# Altium schematic sheet Buck-Boost Converter Ver2.1.SchDoc("Buck-Boost Converter Ver2.1")
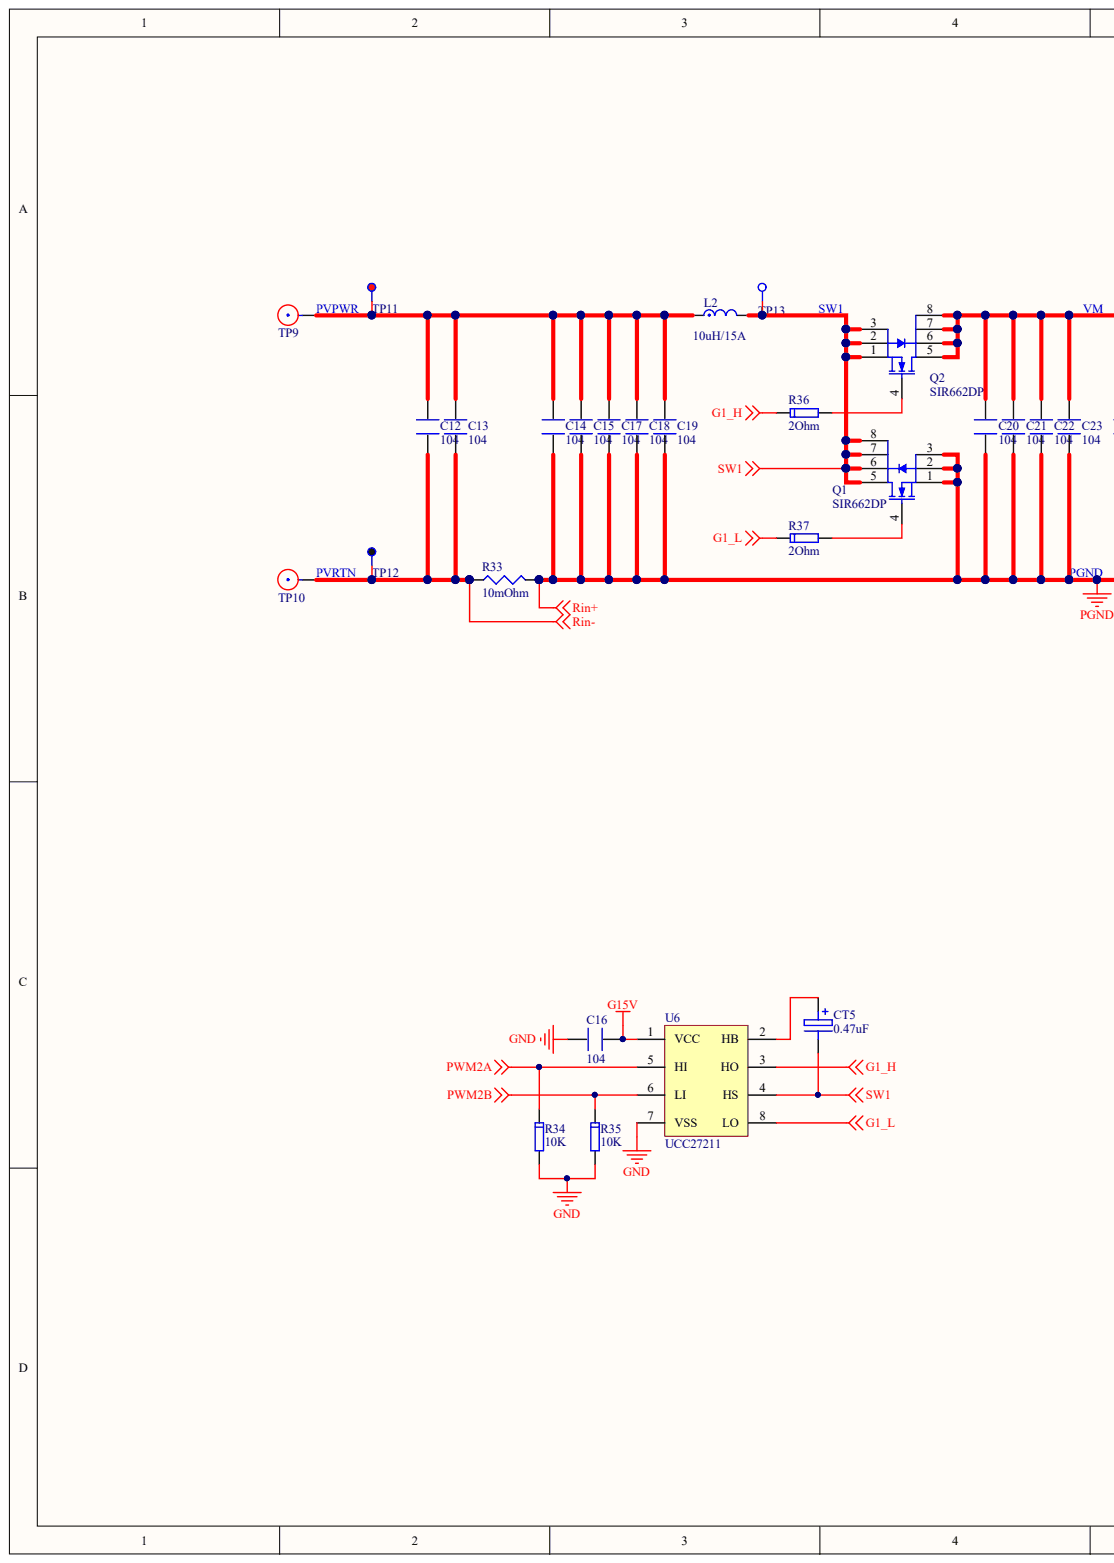
[diagram p0: Buck-Boost Converter Ver2.1.SchDoc, left]
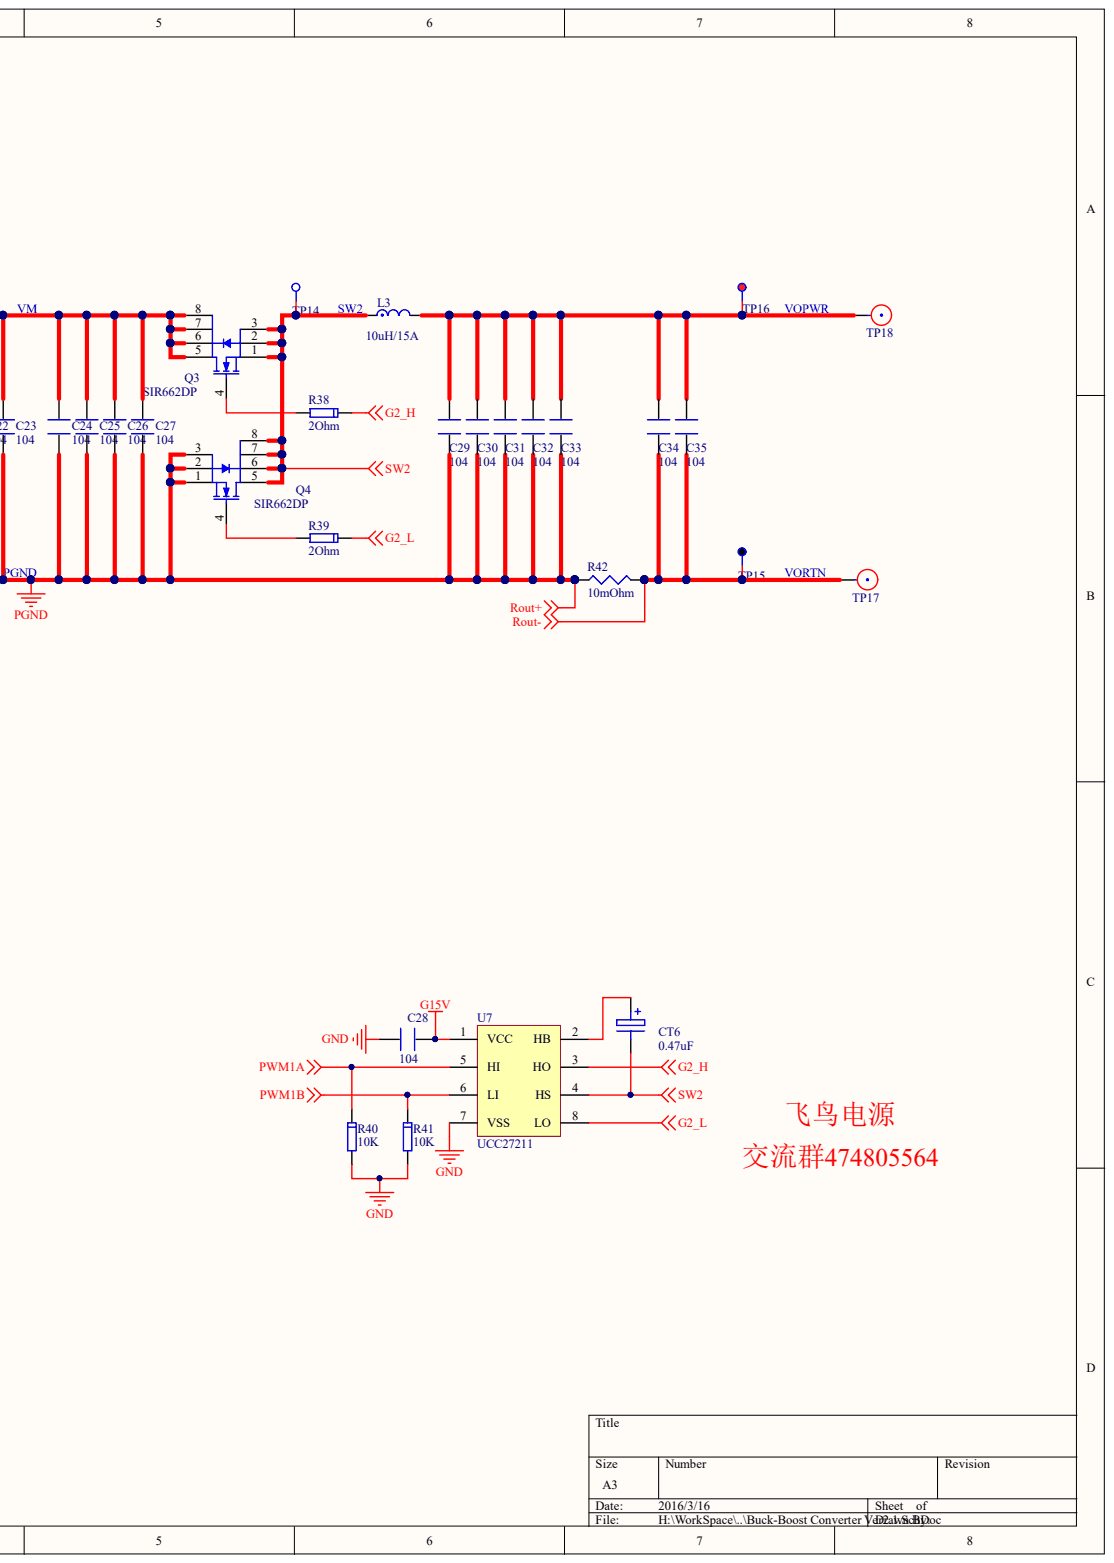
[diagram p1: Buck-Boost Converter Ver2.1.SchDoc, right]

## Parts
C12  104  [C1812]
C13  104  [C1812]
C14  104  [C1812]
C15  104  [C1812]
C16  104  [C0805]
C17  104  [C1812]
C18  104  [C1812]
C19  104  [C1812]
C20  104  [C1210]
C21  104  [C1210]
C22  104  [C1210]
C23  104  [C1210]
C24  104  [C1210]
C25  104  [C1210]
C26  104  [C1210]
C27  104  [C1210]
C28  104  [C0805]
C29  104  [C1812]
C30  104  [C1812]
C31  104  [C1812]
C32  104  [C1812]
C33  104  [C1812]
C34  104  [C1812]
C35  104  [C1812]
CT5  0.47uF  [C1206]
CT6  0.47uF  [C1206]
L2  10uH/15A  [L1260]
L3  10uH/15A  [L1260]
Q1  SIR662DP  [TDSON-8]
Q2  SIR662DP  [TDSON-8]
Q3  SIR662DP  [TDSON-8]
Q4  SIR662DP  [TDSON-8]
R33  10mOhm  [R2512]
R34  10K  [R0603]
R35  10K  [R0603]
R36  2Ohm  [R0603]
R37  2Ohm  [R0603]
R38  2Ohm  [R0603]
R39  2Ohm  [R0603]
R40  10K  [R0603]
R41  10K  [R0603]
R42  10mOhm  [R2512]
TP9  TestPoint  [PCB-11(M3)]  (value not drawn)
TP10  TestPoint  [PCB-11(M3)]  (value not drawn)
TP11  5005  [Keystone5005]  (value not drawn)
TP12  5006  [Keystone5006]  (value not drawn)
TP13  5007  [Keystone5007]  (value not drawn)
TP14  5007  [Keystone5007]  (value not drawn)
TP15  5006  [Keystone5006]  (value not drawn)
TP16  5005  [Keystone5005]  (value not drawn)
TP17  TestPoint  [PCB-11(M3)]  (value not drawn)
TP18  TestPoint  [PCB-11(M3)]  (value not drawn)
U6  UCC27211  [SOP8]
U7  UCC27211  [SOP8]

## Nets
G1_H: R36.1 U6.3(HO)
G1_L: R37.1 U6.8(LO)
G2_H: R38.1 U7.3(HO)
G2_L: R39.1 U7.8(LO)
G15V: C16.1 C28.2 U6.1(VCC) U7.1(VCC)
GND: C16.2 C28.1 R34.2 R35.2 R40.2 R41.2 U6.7(VSS) U7.7(VSS)
PWM1A: R40.1 U7.5(HI)
PWM1B: R41.1 U7.6(LI)
PWM2A: R34.1 U6.5(HI)
PWM2B: R35.1 U6.6(LI)
PGND: C14.2 C15.2 C17.2 C18.2 C19.2 C20.2 C21.2 C22.2 C23.2 C24.2 C25.2 C26.2 C27.2 C29.2 C30.2 C31.2 C32.2 C33.2 Q1.1 Q1.2 Q1.3 Q4.1 Q4.2 Q4.3 R33.2 R42.1
PVPWR: C12.1 C13.1 C14.1 C15.1 C17.1 C18.1 C19.1 L2.1 TP9.1 TP11.1
PVRTN: C12.2 C13.2 R33.1 TP10.1 TP12.1
SW1: CT5.2 L2.2 Q1.5 Q1.6 Q1.7 Q1.8 Q2.1 Q2.2 Q2.3 TP13.1 U6.4(HS)
SW2: CT6.2 L3.1 Q3.1 Q3.2 Q3.3 Q4.5 Q4.6 Q4.7 Q4.8 TP14.1 U7.4(HS)
VM: C20.1 C21.1 C22.1 C23.1 C24.1 C25.1 C26.1 C27.1 Q2.5 Q2.6 Q2.7 Q2.8 Q3.5 Q3.6 Q3.7 Q3.8
VOPWR: C29.1 C30.1 C31.1 C32.1 C33.1 C34.1 C35.1 L3.2 TP16.1 TP18.1
VORTN: C34.2 C35.2 R42.2 TP15.1 TP17.1
NetCT5_1: CT5.1 U6.2(HB)
NetCT6_1: CT6.1 U7.2(HB)
NetQ1_4: Q1.4 R37.2
NetQ2_4: Q2.4 R36.2
NetQ3_4: Q3.4 R38.2
NetQ4_4: Q4.4 R39.2

## Library data of the parts
C12-C35 = Capacitor: Component Kind: Standard; Library Name: PP_SCHlib_Altium.SchLib
CT5 CT6 = CAP Tantalum: Component Kind: Standard; Library Name: PP_SCH.SchLib
L2 L3 = INDUTOR: Component Kind: Standard; Library Name: PP_SCH.SchLib
Q1-Q4 = MOSFET: Component Kind: Standard; Description: SIR662DP; Library Name: PP_SCH.SchLib
R33 R42 = R: Component Kind: Standard; Library Name: PP_SCH.SchLib
R34-R41 = Resistor: Component Kind: Standard; Library Name: PP_SCH.SchLib
TP11 TP16 = 5005: Test Point, Compact, Red, TH; Manufacturer: Keystone; CompType: Test Point Loop; U/M: EA; Component Kind: Standard; ComponentLink1Description: Datasheet Link; ComponentLink1URL: http://www.keyelco.com/userAssets/file/M60-2p60.pdf; LatestRevisionDate: 2013-06-21; Library Name: PP_SCHlib_Altium.SchLib; MountType: TH; PackageReference: Red Compact Testpoint; PartNumber: 5005; RoHS: Y; Supplier 1: Digi-Key; Supplier Part Number 1: 5005K-ND; SymbolVersion: 2008-04-04; Value: Red; Publisher: Texas Instruments
TP12 TP15 = 5006: Test Point, Compact, Black, TH; Manufacturer: Keystone; CompType: Test Point Loop; U/M: EA; Component Kind: Standard; ComponentLink1Description: Datasheet Link; ComponentLink1URL: http://www.keyelco.com/userAssets/file/M60-2p60.pdf; LatestRevisionDate: 2013-06-21; Library Name: PP_SCHlib_Altium.SchLib; MountType: TH; PackageReference: Black Compact Testpoint; PartNumber: 5006; RoHS: Y; Supplier 1: Digi-Key; Supplier Part Number 1: 5006K-ND; SymbolVersion: 2008-04-04; Value: Black; Publisher: Texas Instruments
TP13 TP14 = 5007: Test Point, Compact, White, TH; Manufacturer: Keystone; CompType: Test Point Loop; U/M: EA; Component Kind: Standard; ComponentLink1Description: Datasheet Link; ComponentLink1URL: http://www.keyelco.com/userAssets/file/M60-2p60.pdf; LatestRevisionDate: 2013-08-13; Library Name: PP_SCHlib_Altium.SchLib; MountType: TH; PackageReference: White Compact Testpoint; PartNumber: 5007; RoHS: Y; Supplier 1: Digi-Key; Supplier Part Number 1: 5007K-ND; SymbolVersion: 2008-04-04; Value: White; Publisher: Texas Instruments
U6 U7 = UCC27211: Gate drive,MOSFET、IGBT drive; Component Kind: Standard; Library Name: PP_SCHlib_Altium.SchLib
TP9 TP10 TP17 TP18 = TestPoint: Component Kind: Standard; Library Name: PP_SCH.SchLib
